# Altium schematic sheet AFE.SchDoc
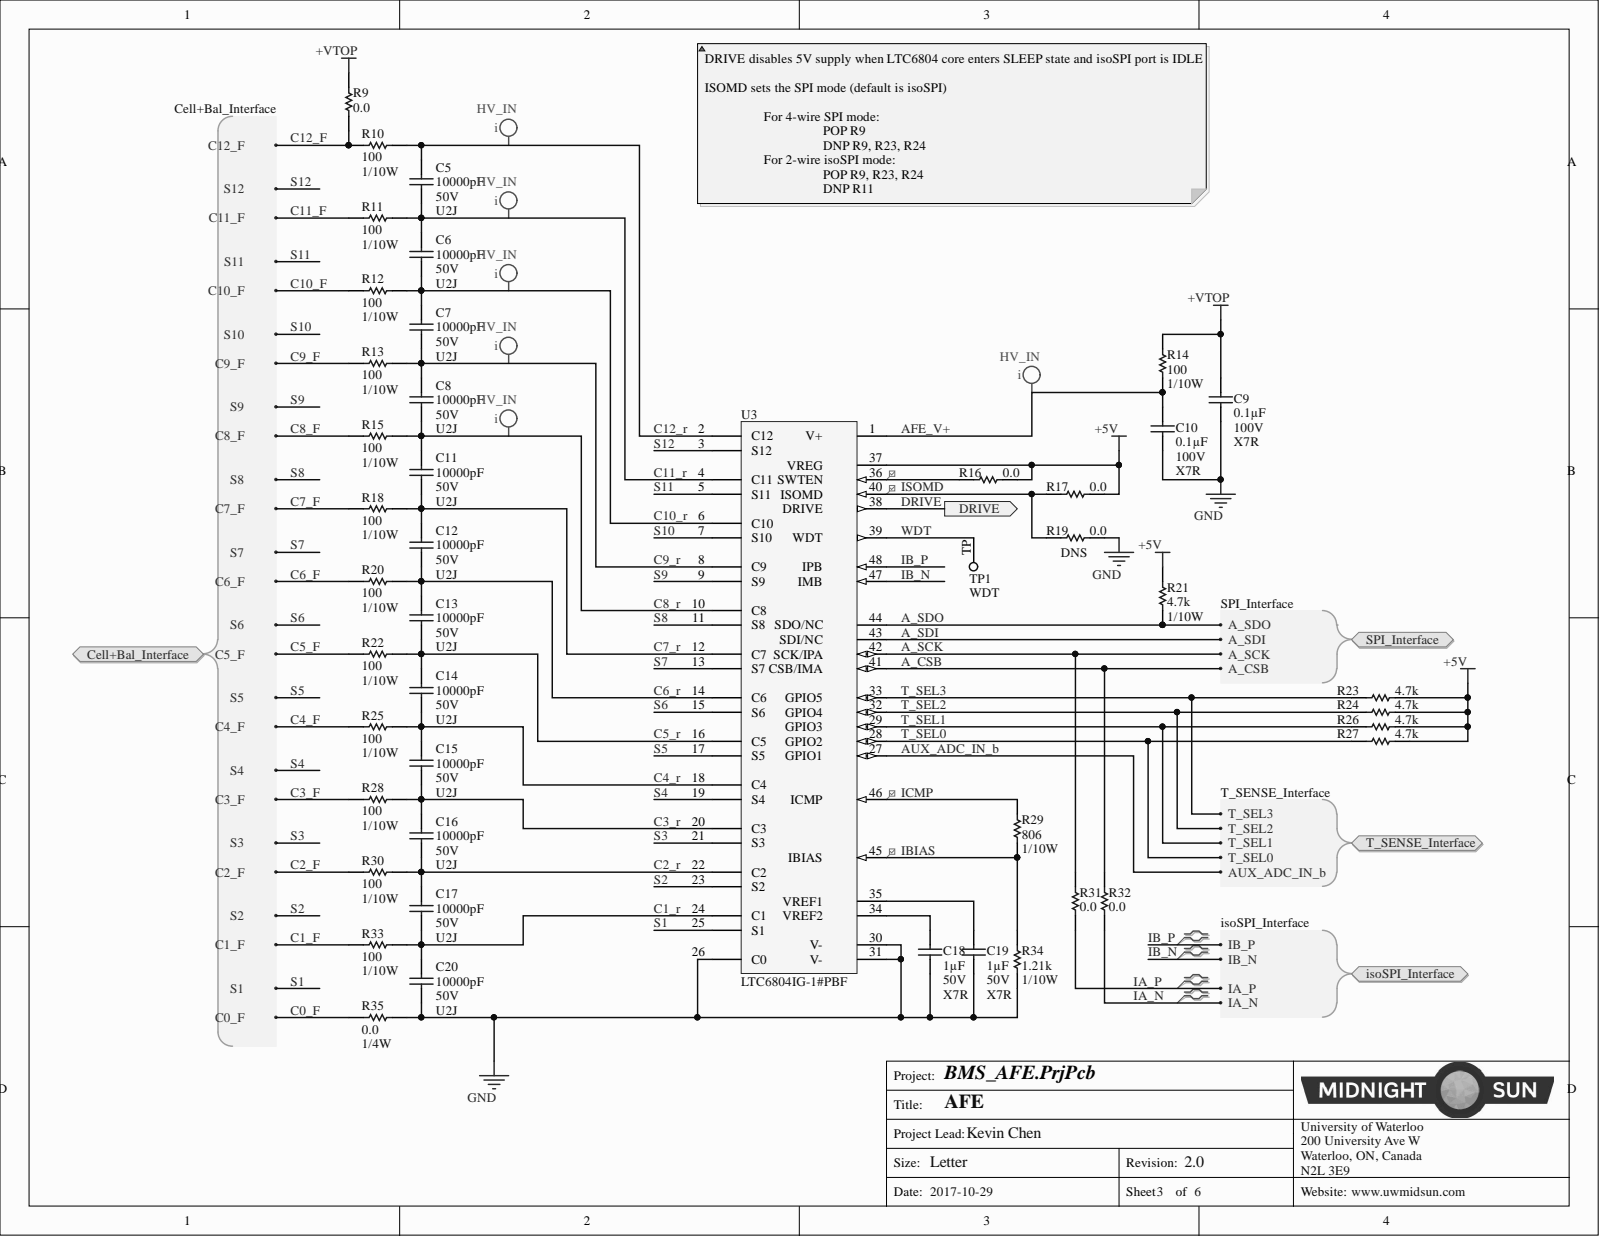
[diagram p0: AFE.SchDoc]

## Parts
C5  Capacitance: 10000pF  Temperature Coefficient: U2J  Voltage: 50V  [CAP, 0603]  (hidden comment: CAP CER 10nF 50V 5% X7R 0603)
C6  Capacitance: 10000pF  Temperature Coefficient: U2J  Voltage: 50V  [CAP, 0603]  (hidden comment: CAP CER 10nF 50V 5% X7R 0603)
C7  Capacitance: 10000pF  Temperature Coefficient: U2J  Voltage: 50V  [CAP, 0603]  (hidden comment: CAP CER 10nF 50V 5% X7R 0603)
C8  Capacitance: 10000pF  Temperature Coefficient: U2J  Voltage: 50V  [CAP, 0603]  (hidden comment: CAP CER 10nF 50V 5% X7R 0603)
C9  Capacitance: 0.1µF  Temperature Coefficient: X7R  Voltage: 100V  [CAP, 0805]  (hidden comment: CAP CER 0.1UF 100V 10% X7R 0805)
C10  Capacitance: 0.1µF  Temperature Coefficient: X7R  Voltage: 100V  [CAP, 0805]  (hidden comment: CAP CER 0.1UF 100V 10% X7R 0805)
C11  Capacitance: 10000pF  Temperature Coefficient: U2J  Voltage: 50V  [CAP, 0603]  (hidden comment: CAP CER 10nF 50V 5% X7R 0603)
C12  Capacitance: 10000pF  Temperature Coefficient: U2J  Voltage: 50V  [CAP, 0603]  (hidden comment: CAP CER 10nF 50V 5% X7R 0603)
C13  Capacitance: 10000pF  Temperature Coefficient: U2J  Voltage: 50V  [CAP, 0603]  (hidden comment: CAP CER 10nF 50V 5% X7R 0603)
C14  Capacitance: 10000pF  Temperature Coefficient: U2J  Voltage: 50V  [CAP, 0603]  (hidden comment: CAP CER 10nF 50V 5% X7R 0603)
C15  Capacitance: 10000pF  Temperature Coefficient: U2J  Voltage: 50V  [CAP, 0603]  (hidden comment: CAP CER 10nF 50V 5% X7R 0603)
C16  Capacitance: 10000pF  Temperature Coefficient: U2J  Voltage: 50V  [CAP, 0603]  (hidden comment: CAP CER 10nF 50V 5% X7R 0603)
C17  Capacitance: 10000pF  Temperature Coefficient: U2J  Voltage: 50V  [CAP, 0603]  (hidden comment: CAP CER 10nF 50V 5% X7R 0603)
C18  Capacitance: 1µF  Temperature Coefficient: X7R  Voltage: 50V  [CAP, 0603]  (hidden comment: CAP CER 1UF 50V 10% X7R 0603)
C19  Capacitance: 1µF  Temperature Coefficient: X7R  Voltage: 50V  [CAP, 0603]  (hidden comment: CAP CER 1UF 50V 10% X7R 0603)
C20  Capacitance: 10000pF  Temperature Coefficient: U2J  Voltage: 50V  [CAP, 0603]  (hidden comment: CAP CER 10nF 50V 5% X7R 0603)
R9  Resistance: 0.0  [RES, 0603]  (hidden comment: RES 0.0 OHM 1/4W 0603)
R10  RES 100 OHM 1% 1/10W 0603  [RES, 0603]  (value not drawn)
R11  RES 100 OHM 1% 1/10W 0603  [RES, 0603]  (value not drawn)
R12  RES 100 OHM 1% 1/10W 0603  [RES, 0603]  (value not drawn)
R13  RES 100 OHM 1% 1/10W 0603  [RES, 0603]  (value not drawn)
R14  Power: 1/10W  [RES, 0603]  (hidden comment: RES 100 OHM 1% 1/10W 0603)
R15  RES 100 OHM 1% 1/10W 0603  [RES, 0603]  (value not drawn)
R16  Resistance: 0.0  [RES, 0603]  (hidden comment: RES 0.0 OHM 1/4W 0603)
R17  Resistance: 0.0  [RES, 0603]  (hidden comment: RES 0.0 OHM 1/4W 0603)
R18  RES 100 OHM 1% 1/10W 0603  [RES, 0603]  (value not drawn)
R19  Resistance: 0.0  [RES, 0603]  (hidden comment: RES 0.0 OHM 1/4W 0603)
R20  RES 100 OHM 1% 1/10W 0603  [RES, 0603]  (value not drawn)
R21  Power (Watts): 1/10W  Resistance (Ohms): 4.7k  [RES, 0603]  (hidden comment: RES 4.7K OHM 1% 1/10W 0603)
R22  RES 100 OHM 1% 1/10W 0603  [RES, 0603]  (value not drawn)
R23  Resistance (Ohms): 4.7k  [RES, 0603]  (hidden comment: RES 4.7K OHM 1% 1/10W 0603)
R24  Resistance (Ohms): 4.7k  [RES, 0603]  (hidden comment: RES 4.7K OHM 1% 1/10W 0603)
R25  RES 100 OHM 1% 1/10W 0603  [RES, 0603]  (value not drawn)
R26  Resistance (Ohms): 4.7k  [RES, 0603]  (hidden comment: RES 4.7K OHM 1% 1/10W 0603)
R27  Resistance (Ohms): 4.7k  [RES, 0603]  (hidden comment: RES 4.7K OHM 1% 1/10W 0603)
R28  RES 100 OHM 1% 1/10W 0603  [RES, 0603]  (value not drawn)
R29  Power: 1/10W  [RES, 0603]  (hidden comment: RES 806 OHM 1% 1/10W 0603)
R30  RES 100 OHM 1% 1/10W 0603  [RES, 0603]  (value not drawn)
R31  Resistance: 0.0  [RES, 0603]  (hidden comment: RES 0.0 OHM 1/4W 0603)
R32  Resistance: 0.0  [RES, 0603]  (hidden comment: RES 0.0 OHM 1/4W 0603)
R33  RES 100 OHM 1% 1/10W 0603  [RES, 0603]  (value not drawn)
R34  Power: 1/10W  Resistance: 1.21k  [RES, 0603]  (hidden comment: RES 1.21K OHM 1% 1/10W 0603)
R35  Power (Watts): 1/4W  Resistance: 0.0  [RES, 0603]  (hidden comment: RES 0.0 OHM 1/4W 0603)
TP1  WDT  [Test Point]
U3  Manufacturer Part Number 1: LTC6804IG-1#PBF  [SSOP50P780X200-48N]  (hidden comment: IC MONITOR BATT STACK 48SSOP)

## Ports
CELL+BAL_INTERFACE, CELL+BAL_INTERFACE.C0_F, CELL+BAL_INTERFACE.C1_F, CELL+BAL_INTERFACE.C2_F, CELL+BAL_INTERFACE.C3_F, CELL+BAL_INTERFACE.C4_F, CELL+BAL_INTERFACE.C5_F, CELL+BAL_INTERFACE.C6_F, CELL+BAL_INTERFACE.C7_F, CELL+BAL_INTERFACE.C8_F, CELL+BAL_INTERFACE.C9_F, CELL+BAL_INTERFACE.C10_F, CELL+BAL_INTERFACE.C11_F, CELL+BAL_INTERFACE.C12_F, CELL+BAL_INTERFACE.S1, CELL+BAL_INTERFACE.S2, CELL+BAL_INTERFACE.S3, CELL+BAL_INTERFACE.S4, CELL+BAL_INTERFACE.S5, CELL+BAL_INTERFACE.S6, CELL+BAL_INTERFACE.S7, CELL+BAL_INTERFACE.S8, CELL+BAL_INTERFACE.S9, CELL+BAL_INTERFACE.S10, CELL+BAL_INTERFACE.S11, CELL+BAL_INTERFACE.S12, DRIVE, ISOSPI_INTERFACE, ISOSPI_INTERFACE.IA_N, ISOSPI_INTERFACE.IA_P, ISOSPI_INTERFACE.IB_N, ISOSPI_INTERFACE.IB_P, SPI_INTERFACE, SPI_INTERFACE.A_CSB, SPI_INTERFACE.A_SCK, SPI_INTERFACE.A_SDI, SPI_INTERFACE.A_SDO, T_SENSE_INTERFACE, T_SENSE_INTERFACE.AUX_ADC_IN_B, T_SENSE_INTERFACE.T_SEL0, T_SENSE_INTERFACE.T_SEL1, T_SENSE_INTERFACE.T_SEL2, T_SENSE_INTERFACE.T_SEL3

## Nets
+5V: R16.1 R17.2 R21.1 R23.2 R24.2 R26.2 R27.2 U3.37
+VTOP: C9.1 R9.1 R14.1
GND: C9.2 C10.2 C18.2 C19.2 C20.2 R19.2 R34.2 R35.2 U3.26(C0) U3.30 U3.31
A_CSB: R32.1 U3.41 ; port SPI_INTERFACE
A_SCK: R31.1 U3.42 ; port SPI_INTERFACE
A_SDI: U3.43 ; port SPI_INTERFACE
A_SDO: R21.2 U3.44 ; port SPI_INTERFACE
AFE_V+: C10.1 R14.2 U3.1
AUX_ADC_IN_B: U3.27 ; port T_SENSE_INTERFACE
C0_F: R35.1 ; port CELL+BAL_INTERFACE
C1_F: R33.1 ; port CELL+BAL_INTERFACE
C1_R: C17.2 C20.1 R33.2 U3.24(C1)
C2_F: R30.1 ; port CELL+BAL_INTERFACE
C2_R: C16.2 C17.1 R30.2 U3.22(C2)
C3_F: R28.1 ; port CELL+BAL_INTERFACE
C3_R: C15.2 C16.1 R28.2 U3.20(C3)
C4_F: R25.1 ; port CELL+BAL_INTERFACE
C4_R: C14.2 C15.1 R25.2 U3.18(C4)
C5_F: R22.1 ; port CELL+BAL_INTERFACE
C5_R: C13.2 C14.1 R22.2 U3.16(C5)
C6_F: R20.1 ; port CELL+BAL_INTERFACE
C6_R: C12.2 C13.1 R20.2 U3.14(C6)
C7_F: R18.1 ; port CELL+BAL_INTERFACE
C7_R: C11.2 C12.1 R18.2 U3.12(C7)
C8_F: R15.1 ; port CELL+BAL_INTERFACE
C8_R: C8.2 C11.1 R15.2 U3.10(C8)
C9_F: R13.1 ; port CELL+BAL_INTERFACE
C9_R: C7.2 C8.1 R13.2 U3.8(C9)
C10_F: R12.1 ; port CELL+BAL_INTERFACE
C10_R: C6.2 C7.1 R12.2 U3.6(C10)
C11_F: R11.1 ; port CELL+BAL_INTERFACE
C11_R: C5.2 C6.1 R11.2 U3.4
C12_F: R9.2 R10.1 ; port CELL+BAL_INTERFACE
C12_R: C5.1 R10.2 U3.2(C12)
DRIVE: U3.38 ; port DRIVE
IA_N: R32.2 ; port ISOSPI_INTERFACE
IA_P: R31.2 ; port ISOSPI_INTERFACE
IB_N: U3.47 ; port ISOSPI_INTERFACE
IB_P: U3.48 ; port ISOSPI_INTERFACE
IBIAS: R29.2 R34.1 U3.45
ICMP: R29.1 U3.46
ISOMD: R17.1 R19.1 U3.40
S1: U3.25(S1) ; port CELL+BAL_INTERFACE
S2: U3.23(S2) ; port CELL+BAL_INTERFACE
S3: U3.21(S3) ; port CELL+BAL_INTERFACE
S4: U3.19(S4) ; port CELL+BAL_INTERFACE
S5: U3.17(S5) ; port CELL+BAL_INTERFACE
S6: U3.15(S6) ; port CELL+BAL_INTERFACE
S7: U3.13(S7_CSB/IMA) ; port CELL+BAL_INTERFACE
S8: U3.11(S8) ; port CELL+BAL_INTERFACE
S9: U3.9(S9) ; port CELL+BAL_INTERFACE
S10: U3.7(S10) ; port CELL+BAL_INTERFACE
S11: U3.5(S11) ; port CELL+BAL_INTERFACE
S12: U3.3(S12) ; port CELL+BAL_INTERFACE
T_SEL0: R27.1 U3.28 ; port T_SENSE_INTERFACE
T_SEL1: R26.1 U3.29 ; port T_SENSE_INTERFACE
T_SEL2: R24.1 U3.32 ; port T_SENSE_INTERFACE
T_SEL3: R23.1 U3.33 ; port T_SENSE_INTERFACE
WDT: TP1.TP U3.39
NetC18_1: C18.1 U3.34
NetC19_1: C19.1 U3.35
NetR16_2: R16.2 U3.36

## Library data of the parts
C5-C8 C11-C17 C20 = CAP CER 10nF 50V 5% X7R 0603: 10000pF ±5% 50V Ceramic Capacitor U2J 0603 (1608 Metric); Manufacturer 1: KEMET; Manufacturer Part Number 1: C0603C103J5JAC7867; Component Kind: Standard; ComponentLink1Description: http://www.kemet.com/docfinder?Partnumber=C0603C103J5JAC7867; ComponentLink1URL: http://www.kemet.com/docfinder?Partnumber=C0603C103J5JAC7867; Failure Rate: -; Height - Seated (Max): -; Lead Spacing: -; Lead Style: -; Mounting Type: Surface Mount, MLCC; Operating Temperature: -55°C ~ 125°C; Package / Case: 0603 (1608 Metric); Packaging: Cut Tape (CT); Part Status: Active; Ratings: -; Size / Dimension: 0.063" L x 0.032" W (1.60mm x 0.80mm); Supplier 1: Digi-Key; Supplier Part Number 1: 399-13384-1-ND; Thickness (Max): 0.034" (0.87mm); Tolerance: ±5%; Library Name: Schematic Diagrams.SchLib
C9 C10 = CAP CER 0.1UF 100V 10% X7R 0805: 0.1µF ±10% 100V Ceramic Capacitor X7R 0805 (2012 Metric); Manufacturer 1: Murata Electronics North America; Manufacturer Part Number 1: GCM21BR72A104KA37L; Component Kind: Standard; ComponentLink1Description: http://www.murata.com/~/media/webrenewal/support/library/catalog/products/capacitor/mlcc/c03e.ashx; ComponentLink1URL: http://www.murata.com/~/media/webrenewal/support/library/catalog/products/capacitor/mlcc/c03e.ashx; Height - Seated (Max): -; Lead Spacing: -; Lead Style: -; Mounting Type: Surface Mount, MLCC; Operating Temperature: -55°C ~ 125°C; Package / Case: 0805 (2012 Metric); Packaging: Cut Tape (CT); Part Status: Active; Ratings: AEC-Q200; Size / Dimension: 0.079" L x 0.049" W (2.00mm x 1.25mm); Supplier 1: Digi-Key; Supplier Part Number 1: 490-4789-1-ND; Thickness (Max): 0.055" (1.40mm); Tolerance: ±10%; Library Name: Schematic Diagrams.SchLib
C18 C19 = CAP CER 1UF 50V 10% X7R 0603: 1µF ±10% 50V Ceramic Capacitor X7R 0603 (1608 Metric); Manufacturer 1: Taiyo Yuden; Manufacturer Part Number 1: UMK107AB7105KA-T; Component Kind: Standard; ComponentLink1Description: http://www.yuden.co.jp/productdata/catalog/en/mlcc_all_e.pdf; ComponentLink1URL: http://www.yuden.co.jp/productdata/catalog/en/mlcc_all_e.pdf; Height - Seated (Max): -; Lead Spacing: -; Lead Style: -; Mounting Type: Surface Mount, MLCC; Operating Temperature: -55°C ~ 125°C; Package / Case: 0603 (1608 Metric); Packaging: Cut Tape (CT); Part Status: Active; Ratings: -; Size / Dimension: 0.063" L x 0.031" W (1.60mm x 0.80mm); Supplier 1: Digi-Key; Supplier Part Number 1: 587-3247-1-ND; Thickness (Max): 0.037" (0.95mm); Tolerance: ±10%; Library Name: Schematic Diagrams.SchLib
R9 R16 R17 R19 R31 R32 R35 = RES 0.0 OHM 1/4W 0603: RES SMD 0.0 OHM JUMPER 1/4W 0603; Manufacturer 1: Vishay Dale; Manufacturer Part Number 1: CRCW06030000Z0EAHP; Component Kind: Standard; ComponentLink1Description: http://www.vishay.com/docs/20043/crcwhpe3.pdf; ComponentLink1URL: http://www.vishay.com/docs/20043/crcwhpe3.pdf; Composition: Thick Film; Failure Rate: -; Height - Seated (Max): 0.022" (0.55mm); Operating Temperature: -55°C ~ 155°C; Package / Case: 0603 (1608 Metric); Packaging: Cut Tape (CT); Part Status: Active; Size / Dimension: 0.063" L x 0.033" W (1.60mm x 0.85mm); Supplier 1: Digi-Key; Supplier Device Package: 0603; Supplier Part Number 1: 541-0.0SBCT-ND; Library Name: Schematic Diagrams.SchLib
  R9 R16 R17 R31 R32: Power (Watts): 1/4W
  R19: DNS: DNS; Power (Watts): 1/4W
R10-R15 R18 R20 R22 R25 R28 R30 R33 = RES 100 OHM 1% 1/10W 0603: 100 Ohm ±1% 0.1W, 1/10W Chip Resistor 0603 (1608 Metric) Moisture Resistant Thick Film; Manufacturer 1: Yageo; Manufacturer Part Number 1: RC0603FR-07100RL; Resistance: 100; Temperature Coefficient: ±100ppm/°C; Component Kind: Standard; ComponentLink1Description: http://www.yageo.com/documents/recent/PYu-RC_Group_51_RoHS_L_7.pdf; ComponentLink1URL: http://www.yageo.com/documents/recent/PYu-RC_Group_51_RoHS_L_7.pdf; Composition: Thick Film; Failure Rate: -; Height - Seated (Max): 0.022" (0.55mm); Operating Temperature: -55°C ~ 155°C; Package / Case: 0603 (1608 Metric); Packaging: Cut Tape (CT); Part Status: Active; Size / Dimension: 0.063" L x 0.031" W (1.60mm x 0.80mm); Supplier 1: Digi-Key; Supplier Device Package: 0603; Supplier Part Number 1: 311-100HRCT-ND; Tolerance: ±1%; Library Name: Schematic Diagrams.SchLib
  R10-R13 R15 R18 R20 R22 R25 R28 R30 R33: Power: 1/10W
R21 R23 R24 R26 R27 = RES 4.7K OHM 1% 1/10W 0603: 4.7k Ohm ±1% 0.1W, 1/10W Chip Resistor 0603 (1608 Metric) Moisture Resistant Thick Film; Manufacturer 1: Yageo; Manufacturer Part Number 1: RC0603FR-074K7L; Description 1: RES SMD 4.7K OHM 1% 1/10W 0603; Temperature Coefficient: ±100ppm/°C; Category: Resistors; Component Kind: Standard; ComponentLink1Description: http://www.yageo.com/documents/recent/PYu-RC_Group_51_RoHS_L_7.pdf; ComponentLink1URL: http://www.yageo.com/documents/recent/PYu-RC_Group_51_RoHS_L_7.pdf; Composition: Thick Film; Failure Rate: -; Features: Moisture Resistant; Height - Seated (Max): 0.022" (0.55mm); Operating Temperature: -55°C ~ 155°C; Package / Case: 0603 (1608 Metric); Packaging: Cut Tape (CT); Part Status: Active; Pricing 1: 1=0.16, 10=0.022, 100=0.0089, 1000=0.00402, 2500=0.00349 (CAD); RoHS: RoHS Compliant; Size / Dimension: 0.063" L x 0.031" W (1.60mm x 0.80mm); Stock 1: 3487566; Supplier 1: Digi-Key; Supplier Device Package: 0603; Supplier Part Number 1: 311-4.70KHRCT-ND; Tolerance: ±1%; Library Name: Schematic Diagrams.SchLib
  R23 R24 R26 R27: Power (Watts): 1/10W
R29 = RES 806 OHM 1% 1/10W 0603: 806 Ohm ±1% 0.1W, 1/10W Chip Resistor 0603 (1608 Metric) Moisture Resistant Thick Film; Manufacturer 1: Yageo; Manufacturer Part Number 1: RC0603FR-07806RL; Resistance: 806; Temperature Coefficient: ±100ppm/°C; Component Kind: Standard; ComponentLink1Description: http://www.yageo.com/documents/recent/PYu-RC_Group_51_RoHS_L_7.pdf; ComponentLink1URL: http://www.yageo.com/documents/recent/PYu-RC_Group_51_RoHS_L_7.pdf; Composition: Thick Film; Failure Rate: -; Height - Seated (Max): 0.022" (0.55mm); Operating Temperature: -55°C ~ 155°C; Package / Case: 0603 (1608 Metric); Packaging: Cut Tape (CT); Part Status: Active; Size / Dimension: 0.063" L x 0.031" W (1.60mm x 0.80mm); Supplier 1: Digi-Key; Supplier Device Package: 0603; Supplier Part Number 1: 311-806HRCT-ND; Tolerance: ±1%; Library Name: Schematic Diagrams.SchLib
R34 = RES 1.21K OHM 1% 1/10W 0603: 1.21k Ohm ±1% 0.1W, 1/10W Chip Resistor 0603 (1608 Metric) Moisture Resistant Thick Film; Manufacturer 1: Yageo; Manufacturer Part Number 1: RC0603FR-071K21L; Temperature Coefficient: ±100ppm/°C; Component Kind: Standard; ComponentLink1Description: http://www.yageo.com/documents/recent/PYu-RC_Group_51_RoHS_L_7.pdf; ComponentLink1URL: http://www.yageo.com/documents/recent/PYu-RC_Group_51_RoHS_L_7.pdf; Composition: Thick Film; Failure Rate: -; Height - Seated (Max): 0.022" (0.55mm); Operating Temperature: -55°C ~ 155°C; Package / Case: 0603 (1608 Metric); Packaging: Cut Tape (CT); Part Status: Active; Size / Dimension: 0.063" L x 0.031" W (1.60mm x 0.80mm); Supplier 1: Digi-Key; Supplier Device Package: 0603; Supplier Part Number 1: 311-1.21KHRCT-ND; Tolerance: ±1%; Library Name: Schematic Diagrams.SchLib
TP1 = Test Point: Component Kind: Standard; Library Name: Schematic Diagrams.SchLib
U3 = IC MONITOR BATT STACK 48SSOP: Battery Battery Monitor IC Multi-Chemistry 48-SSOP; Manufacturer 1: Linear Technology; Fault Protection: Over/Under Voltage; Interface: I²C, SPI; Number of Cells: 1 ~ 12; Battery Chemistry: Multi-Chemistry; Component Kind: Standard; ComponentLink1Description: http://www.linear.com/docs/43171; ComponentLink1URL: http://www.linear.com/docs/43171; Function: Battery Monitor; Operating Temperature: -40°C ~ 85°C (TA); Package / Case: 48-FSOP (0.209", 5.30mm Width); Packaging: Tube; Part Status: Active; Supplier 1: Digi-Key; Supplier Device Package: 48-SSOP; Supplier Part Number 1: LTC6804IG-1#PBF-ND; Library Name: Schematic Diagrams.SchLib
